# Altium schematic sheet Sheet1_WATERALARM.SchDoc(Sheet1_WATERALARM)
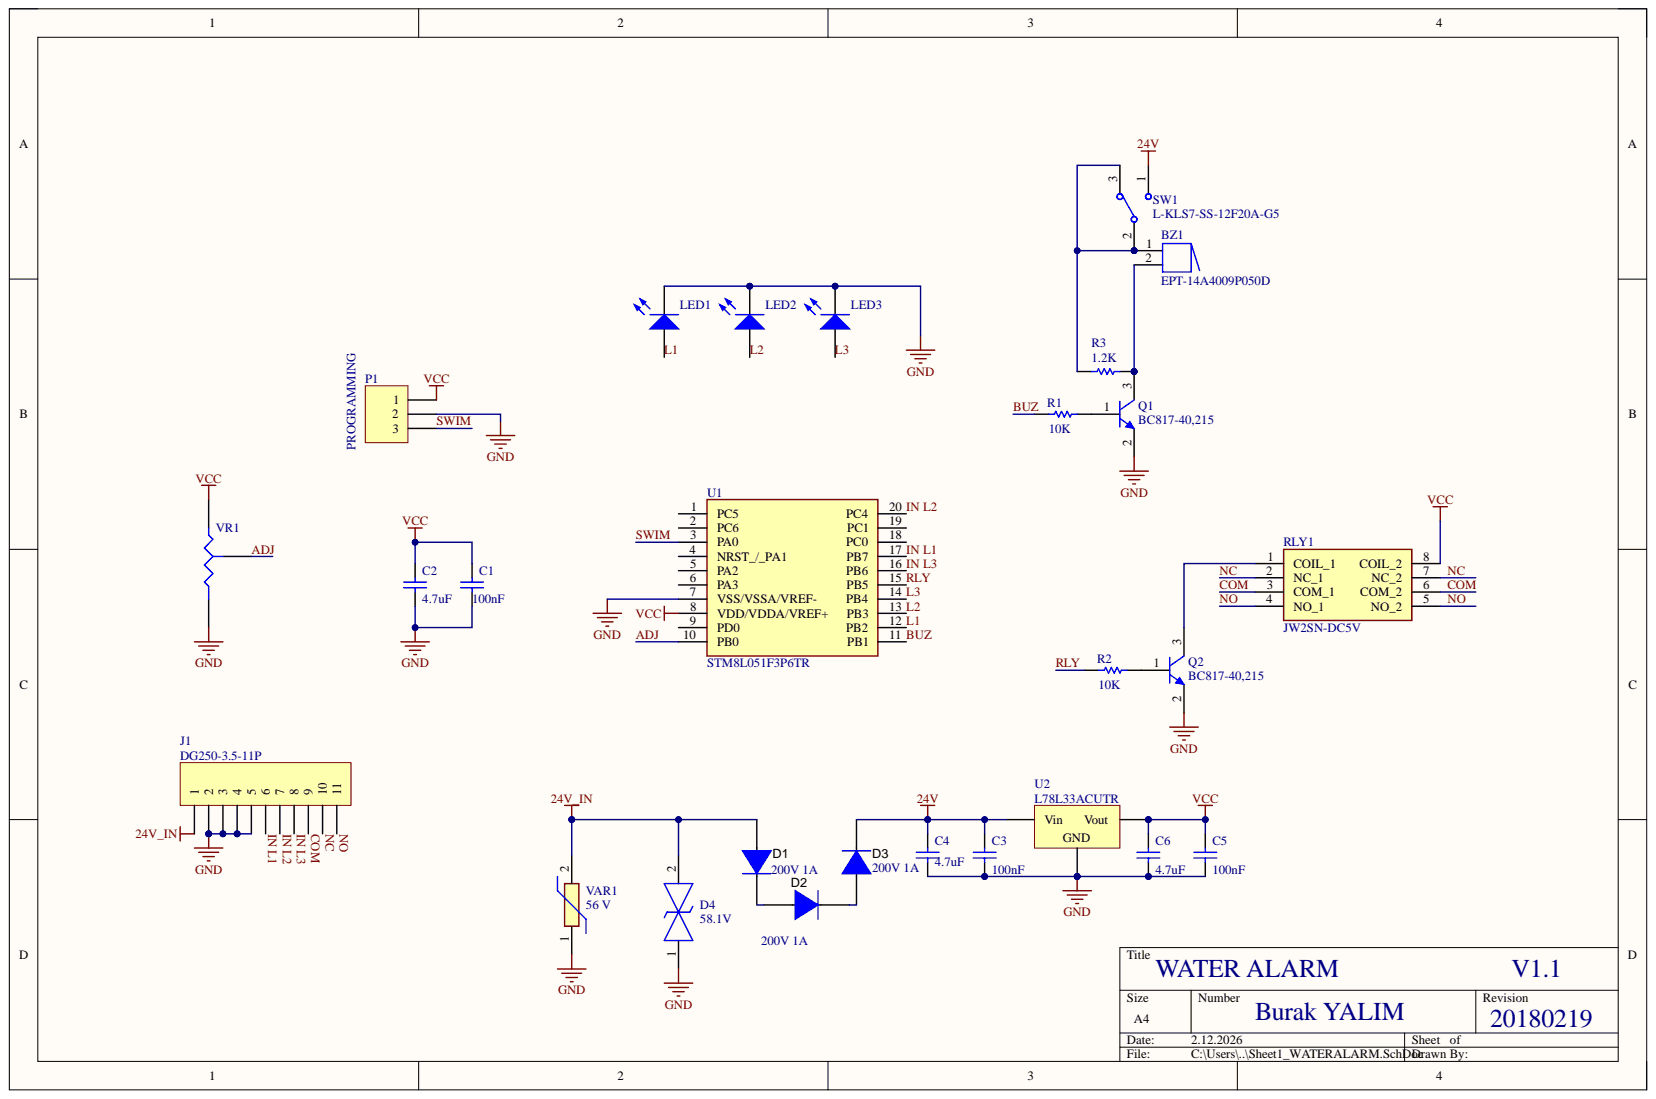
[diagram p0: Sheet1_WATERALARM.SchDoc]

## Parts
BZ1  EPT-14A4009P050D  [piezo buzzer]
C1  value 100nF  [C0805-N]  (hidden comment: CL21B104KBCNNNL)
C2  value 4.7uF  [C0805-N]  (hidden comment: CL21A475KBQNNNE)
C3  value 100nF  [C0805-N]  (hidden comment: CL21B104KBCNNNL)
C4  value 4.7uF  [C0805-N]  (hidden comment: CL21A475KBQNNNE)
C5  value 100nF  [C0805-N]  (hidden comment: CL21B104KBCNNNL)
C6  value 4.7uF  [C0805-N]  (hidden comment: CL21A475KBQNNNE)
D1  value 200V 1A  [SMA]  (hidden comment: US1D_R1_00001)
D2  value 200V 1A  [SMA]  (hidden comment: US1D_R1_00001)
D3  value 200V 1A  [SMA]  (hidden comment: US1D_R1_00001)
D4  value 58.1V  [DIONM5127X250N]  (hidden comment: SMAJ36CA/TR7)
J1  DG250-3.5-11P  [11 pin Terminal sping loaded]
LED1  3R4HD-7-TB  [led 3mm]  (value not drawn)
LED2  3R4HD-7-TB  [led 3mm]  (value not drawn)
LED3  3R4HD-7-TB  [led 3mm]  (value not drawn)
P1  PROGRAMMING  [HDR1X3]  (no BOM)
Q1  BC817-40,215  [SOT-23]
Q2  BC817-40,215  [SOT-23]
R1  value 10K  [C0805-N]  (hidden comment: 0805S8J0103T5E)
R2  value 10K  [C0805-N]  (hidden comment: 0805S8J0103T5E)
R3  value 1.2K  [C1210]  (hidden comment: RI1210L122JT)
RLY1  JW2SN-DC5V  [JW2SNDC5V]
SW1  L-KLS7-SS-12F20A-G5  [Slide Switch]
U1  STM8L051F3P6TR  [SOP65P640X120-20N]
U2  L78L33ACUTR  [SOT89M]
VAR1  Varistor Voltage: 56 V  [ERZV05D180]  (hidden comment: ERZ-V05D560)
VR1  L-KLS4-WH06-2A-103  [Potentiometer]  (value not drawn)

## Nets
24V: C3.2 C4.2 D3.1 SW1.1 U2.1
24V_IN: D1.2 D4.2 J1.1 VAR1.2
GND: C1.1 C2.1 C3.1 C4.1 C5.1 C6.1 D4.1 J1.2 J1.3 J1.4 J1.5 LED1.2 LED2.2 LED3.2 P1.2 Q1.2 Q2.2 U1.7(VSS/VSSA/VREF-) U2.2 VAR1.1 VR1.2
VCC: C1.2 C2.2 C5.2 C6.2 P1.1 RLY1.8(COIL_2) U1.8(VDD/VDDA/VREF+) U2.3 VR1.1
ADJ: U1.10(PB0) VR1.3
BUZ: R1.2 U1.11(PB1)
COM: J1.9 RLY1.3(COM_1) RLY1.6(COM_2)
IN L1: J1.6 U1.17(PB7)
IN L2: J1.7 U1.20(PC4)
IN L3: J1.8 U1.16(PB6)
L1: LED1.1 U1.12(PB2)
L2: LED2.1 U1.13(PB3)
L3: LED3.1 U1.14(PB4)
NC: J1.10 RLY1.2(NC_1) RLY1.7(NC_2)
NO: J1.11 RLY1.4(NO_1) RLY1.5(NO_2)
RLY: R2.2 U1.15(PB5)
SWIM: P1.3 U1.3(PA0)
NetBZ1_1: BZ1.1 R3.2 SW1.2 SW1.3
NetBZ1_2: BZ1.2 Q1.3 R3.1
NetD1_1: D1.1 D2.2
NetD2_1: D2.1 D3.2
NetQ1_1: Q1.1 R1.1
NetQ2_1: Q2.1 R2.1
NetQ2_3: Q2.3 RLY1.1(COIL_1)

Not connected: U1 1(PC5) 2(PC6) 4(NRST_/_PA1) 5(PA2) 6(PA3) 9(PD0) 18(PC0) 19(PC1)

## Library data of the parts
BZ1 = Buzzer: BUZZER PIEZO W/O CIRCUIT 9V 4-KHZ THT; Manufacturer: SANCO; Component Kind: Standard; Library Name: Miscellaneous Devices.IntLib; Manufacturer Part Number: EPT-14A4009P050D
C1 C3 C5 = Cap: MLCC (2012) 0805 100nF 50VDC ±10% X7R; Manufacturer: SAMSUNG; Component Kind: Standard; Library Name: Miscellaneous Devices.IntLib; Manufacturer Part Number: CL21B104KBCNNNL; Signal Integrity: Cap; Simulation: CAP
C2 C4 C6 = Cap: MLCC (2012) 0805 4.7uF 50VDC ±10% X5R; Manufacturer: SAMSUNG; Component Kind: Standard; Library Name: Miscellaneous Devices.IntLib; Manufacturer Part Number: CL21A475KBQNNNE; Signal Integrity: Cap; Simulation: CAP
D1 D3 = MURA160T3G: DIODE U.FAST SINGLE 1A 200V DO214AC (SMA) SMT; Manufacturer: ON Semiconductor; Value: 200V 1A; Component Kind: Standard; ComponentLink1Description: Datasheet link; ComponentLink1URL: http://www.onsemi.com/pub_link/Collateral/MURA160T3-D.PDF; Library Name: PMP30720_RevA.IntLib; Manufacturer Part Number: US1D_R1_00001; Signal Integrity: Diode; Simulation: Dmura160t3
D2 = MURA160T3G: DIODE U.FAST SINGLE 1A 200V DO214AC (SMA) SMT; Manufacturer: ON Semiconductor; Component Kind: Standard; ComponentLink1Description: Datasheet link; ComponentLink1URL: http://www.onsemi.com/pub_link/Collateral/MURA160T3-D.PDF; Library Name: PMP30720_RevA.IntLib; Manufacturer Part Number: US1D_R1_00001; Signal Integrity: Diode; Simulation: Dmura160t3
D4 = SMAJ36CA-AT_TR7: 58.1V Clamp 6.9A Ipp Tvs Diode Surface Mount DO-214AC (SMA); Manufacturer: YAGEO; Component Kind: Standard; Height: 2.5mm; Manufacturer Part Number: SMAJ36CA/TR7
J1 = DG250-3.5-11P: TERM.BLK.3.50MM 11P PCB TYPE GRAY GAOSONG; Manufacturer: DEGSON/GAOSONG; Component Kind: Standard; Manufacturer Part Number: DG250-3.5-11P; Signal Integrity: Connector; Value: DG250-3.5-11P; Library Name: WATERALARM_V1.1.SCHLIB
LED1-LED3 = LED2: LED 3MM RED 30mcd 35° RED/DIFFUSED; Manufacturer: STRONG BASE; Component Kind: Standard; Library Name: Miscellaneous Devices.IntLib; Manufacturer Part Number: 3R4HD-7-TB; Simulation: LED2
P1 = Header 3: Header, 3-Pin; Library Name: Miscellaneous Connectors.IntLib; Signal Integrity: Connector
Q1 Q2 = NPN: TRANSISTOR DIS.500mA 45V NPN SOT23 GEN. SMT; Manufacturer: NXP; Component Kind: Standard; Library Name: Miscellaneous Devices.IntLib; Manufacturer Part Number: BC817-40,215; Signal Integrity: NPN; Simulation: NPN
R1 R2 = Res1: RES.(2012) 0805 10K Ohms 5% 1/8W-S 100PPM; Manufacturer: ROYALOHM; Component Kind: Standard; Library Name: Miscellaneous Devices.IntLib; Manufacturer Part Number: 0805S8J0103T5E; Signal Integrity: Res; Simulation: RESISTOR
R3 = Res1: RES.(3225) 1210 1.2K Ohms 5% 1/2W 200PPM; Manufacturer: HOTTECH; Component Kind: Standard; Library Name: Miscellaneous Devices.IntLib; Manufacturer Part Number: RI1210L122JT; Signal Integrity: Res; Simulation: RESISTOR
RLY1 = JW2SN-DC5V: General Purpose Relay DPDT (2 Form C) 5VDC Coil Through Hole; Manufacturer: Panasonic; Component Kind: Standard; Manufacturer Part Number: JW2SN-DC5V
SW1 = SW-SPDT: SWITCH SLIDE 1P2T 500mA 50V On-On THT V/T; Manufacturer: KLS ELECTRONIC; Component Kind: Standard; Library Name: Miscellaneous Devices.IntLib; Manufacturer Part Number: L-KLS7-SS-12F20A-G5
U1 = STM8L051F3P6TR: Integrated Circuit; Manufacturer_Name: STMicroelectronics; Arrow Part Number: STM8L051F3P6TR; Arrow Price/Stock: https://www.arrow.com/en/products/stm8l051f3p6tr/stmicroelectronics?utm_currency=USD&region=nac; Component Kind: Standard; Datasheet Link: https://www.st.com/resource/en/datasheet/stm8l051f3.pdf; Height: 1.2mm; Manufacturer_Part_Number: STM8L051F3P6TR; Mouser Part Number: 511-STM8L051F3P6TR; Mouser Price/Stock: https://www.mouser.co.uk/ProductDetail/STMicroelectronics/STM8L051F3P6TR?qs=jgnmLK5BaiFksEdVQwFp7A%3D%3D
U2 = Volt Reg: IC-78L33 VOLTAGE REGULATOR 3.3V 100mA SOT89 ST; Manufacturer: STM; Component Kind: Standard; Library Name: Miscellaneous Devices.IntLib; Manufacturer Part Number: L78L33ACUTR; Value: L78L33ACUTR
VAR1 = ERZ-V05D180: 56 V 250 A Varistor 1 Circuit Through Hole Disc 7mm; Manufacturer: Panasonic; Component Kind: Standard; Manufacturer Part Number: ERZ-V05D560; Value: ERZ-V05D560
VR1 = Res Tap: TRIMPOT CARBON V 6MM 10K; Manufacturer: KLS ELECTRONIC; Component Kind: Standard; Library Name: Miscellaneous Devices.IntLib; Manufacturer Part Number: L-KLS4-WH06-2A-103; Signal Integrity: Res Tap; Simulation: POT; Value: 10K
